# Altium schematic sheet ADS.SchDoc(ADS)
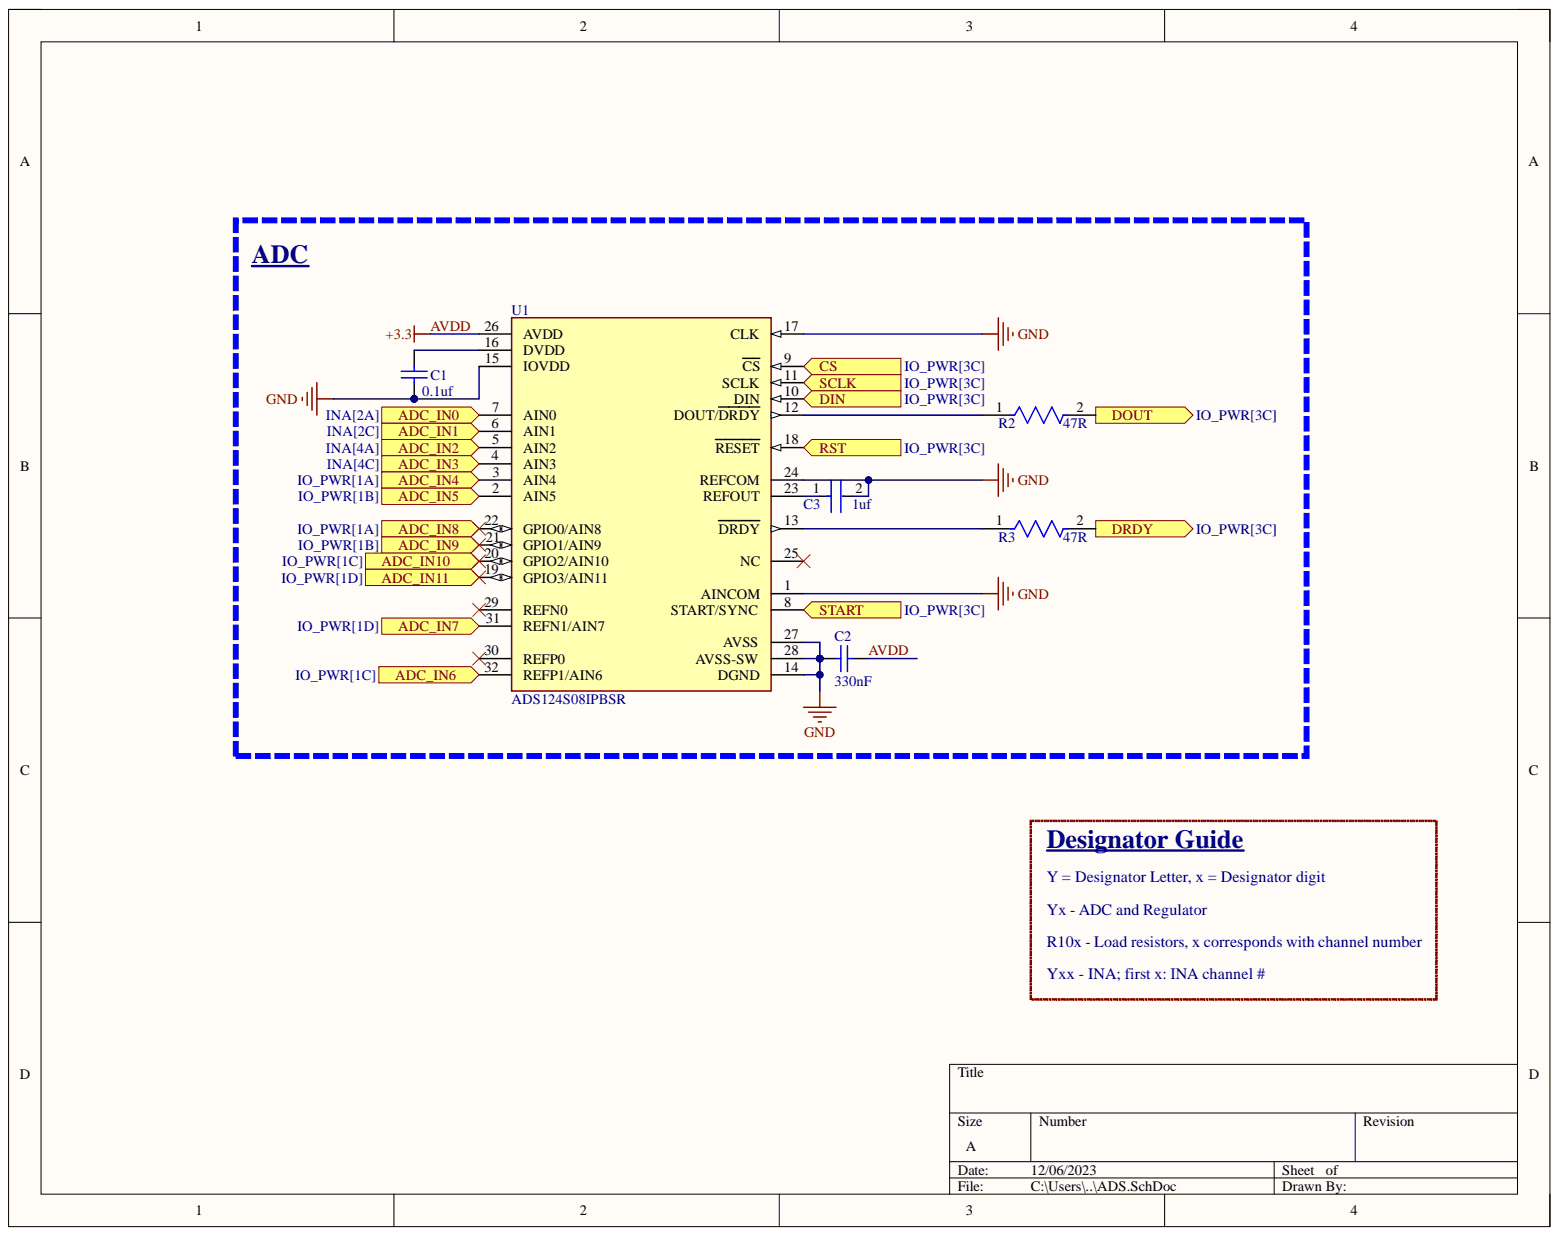
[diagram p0: ADS.SchDoc]

## Parts
C1  0.1uf  [CAPC1005X56X25NL10T15]
C2  330nF  [CAPC1005X56X25NL10T15]
C3  1uf  [FP-1206-L_3_2_0_2-W_1_6_0-IPC_A]
R2  47R  [FP-TFCR0603-IPC_A]
R3  47R  [FP-TFCR0603-IPC_A]
U1  ADS124S08IPBSR  [FP-PBS0032A-MFG]

## Ports
ADC_IN0, ADC_IN1, ADC_IN2, ADC_IN3, ADC_IN4, ADC_IN5, ADC_IN6, ADC_IN7, ADC_IN8, ADC_IN9, ADC_IN10, ADC_IN11, CS, DIN, DOUT, DRDY, RST, SCLK, START

## Nets
GND: C1.1 C2.2 C3.2 U1.1(AINCOM) U1.14(DGND) U1.15(IOVDD) U1.17(CLK) U1.24(REFCOM) U1.27(AVSS) U1.28(AVSS-SW)
ADC_IN0: U1.7(AIN0) ; port ADC_IN0
ADC_IN1: U1.6(AIN1) ; port ADC_IN1
ADC_IN2: U1.5(AIN2) ; port ADC_IN2
ADC_IN3: U1.4(AIN3) ; port ADC_IN3
ADC_IN4: U1.3(AIN4) ; port ADC_IN4
ADC_IN5: U1.2(AIN5) ; port ADC_IN5
ADC_IN6: U1.32(REFP1/AIN6) ; port ADC_IN6
ADC_IN7: U1.31(REFN1/AIN7) ; port ADC_IN7
ADC_IN8: U1.22(GPIO0/AIN8) ; port ADC_IN8
ADC_IN9: U1.21(GPIO1/AIN9) ; port ADC_IN9
ADC_IN10: U1.20(GPIO2/AIN10) ; port ADC_IN10
ADC_IN11: U1.19(GPIO3/AIN11) ; port ADC_IN11
AVDD: C2.1 U1.26(AVDD)
CS: U1.9(CS) ; port CS
DIN: U1.10(DIN) ; port DIN
DOUT: R2.2 ; port DOUT
DRDY: R3.2 ; port DRDY
RST: U1.18(RESET) ; port RST
SCLK: U1.11(SCLK) ; port SCLK
START: U1.8(START/SYNC) ; port START
NetC1_2: C1.2 U1.16(DVDD)
NetC3_1: C3.1 U1.23(REFOUT)
NetR2_1: R2.1 U1.12(DOUT/DRDY)
NetR3_1: R3.1 U1.13(DRDY)

Not connected: U1 25(NC) 29(REFN0) 30(REFP0)

## Library data of the parts
C1 C2 = CMP-1034-02072-2: Manufacturer: AVX Interconnect / Elco; Part Number: 04023D104KAT2A; Component Kind: Standard; Library Name: Altium Content Vault
C3 = CMP-04427-011252-1: Automotive Ceramic Capacitor, 1206, 1uF, 10%, X7R, 15%, 25V; Capacitance: 1uF; Case Code (Imperial): 1206; Case Code (Metric): 3216; Case/Package: 1206; Component Kind: Standard; Construction: Flat; Depth: 1.6mm; Dielectric: X7R; Features: Soft Termination; Height: 1.4mm; Length: 3.2mm; Library Name: Altium Content Vault; Max Operating Temperature: 125°C; Min Operating Temperature: -55°C; Mount: Surface Mount; Package Quantity: 2000; Packaging: Tape and Reel; Radiation Hardening: No; Ratings: AEC-Q200; RoHS Compliant: Yes; Series: FLEXITERM®; Termination: SMD/SMT; Thickness: 0.07inch; Tolerance: 10%; Voltage Rating: 25V; Voltage Rating (DC): 25V; Width: 1.6mm
R2 R3 = CMP-250906-284725-1: RES Thin Film,  500Ω, 0.1%, 0.1W, 25ppm/°C, 0603; Component Kind: Standard; Library Name: Altium Content Vault; RoHS Compliant: Yes
U1 = CMP-04860-000020-1: IC ADC 24BIT SIGMA-DELTA 32LQFP; Interface: SPI; Number of A/D Converters: 1; Number of Bits: 24; Number of Inputs: 12; Sampling Rate: 4000S/s; Case/Package: LQFP; Component Kind: Standard; Library Name: Altium Content Vault; Max Operating Temperature: 125°C; Min Operating Temperature: -50°C; Mount: Surface Mount; Number of Pins: 32; Packaging: Tape and Reel; RoHS Compliant: Yes
